# Altium schematic sheet StepGeneratorHammondMainRev1b.SchDoc(StepGeneratorHammondMainRev1b)
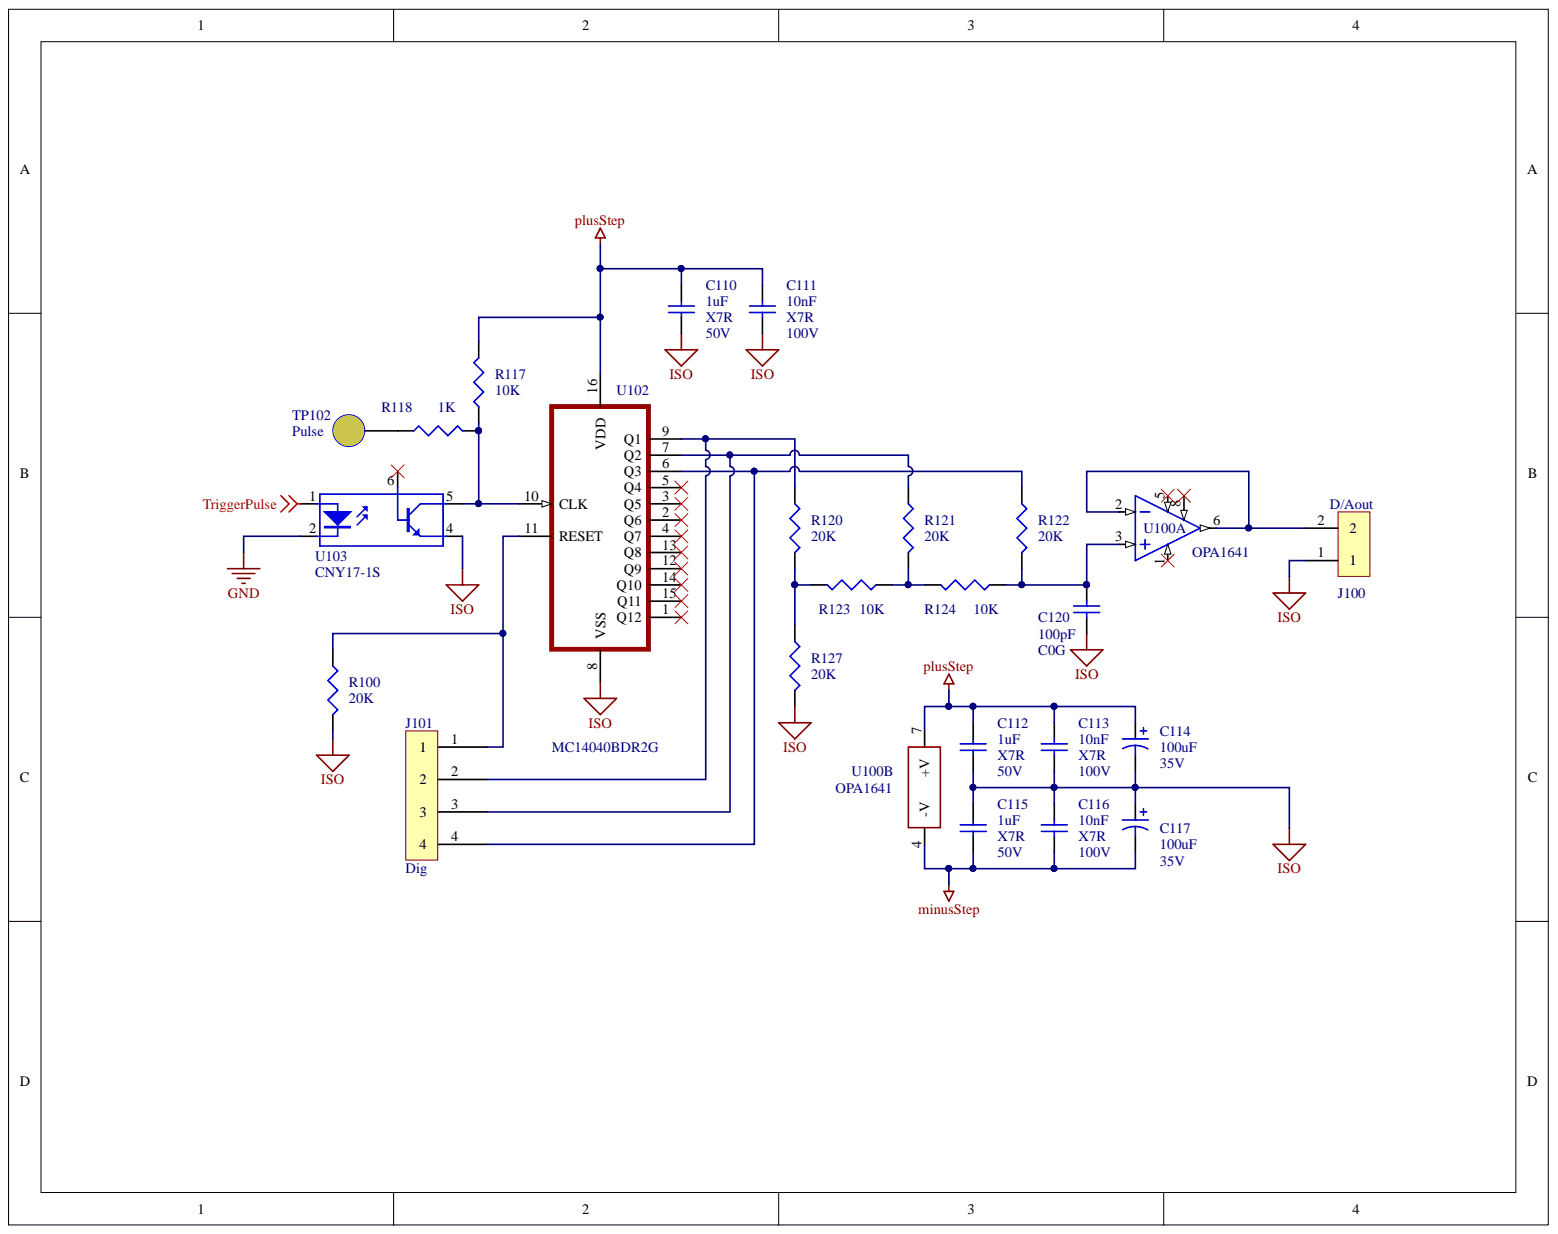
[diagram p0: StepGeneratorHammondMainRev1b.SchDoc]

## Parts
C110  1uF  Voltage Rating (DC): 50V  Dielectric: X7R  [CAPC3216M]
C111  10nF  Voltage Rating (DC): 100V  Dielectric: X7R  [CAPC3216M]
C112  1uF  Voltage Rating (DC): 50V  Dielectric: X7R  [CAPC3216M]
C113  10nF  Voltage Rating (DC): 100V  Dielectric: X7R  [CAPC3216M]
C114  100uF  Voltage Rating: 35V  [CAPPR2.5-6.3X10]
C115  1uF  Voltage Rating (DC): 50V  Dielectric: X7R  [CAPC3216M]
C116  10nF  Voltage Rating (DC): 100V  Dielectric: X7R  [CAPC3216M]
C117  100uF  Voltage Rating: 35V  [CAPPR2.5-6.3X10]
C120  value 100pF  Temperature Coefficient: C0G  [CAPC3216M]  (hidden comment: Cap)
J100  D/Aout  [DTA-100-2]
J101  Dig  [DTA-100-4]
R100  20K  [RESC3216M]
R117  10K  [RESC3216M]
R118  1K  [RESC3216M]
R120  20K  [RESC3216M]
R121  20K  [RESC3216M]
R122  20K  [RESC3216M]
R123  10K  [RESC3216M]
R124  10K  [RESC3216M]
R127  20K  [RESC3216M]
TP102  Pulse  [TP-.038]
U100  OPA1641  [SOIC127P600X168_HS-8N]  (parts A,B)
U102  MC14040BDR2G  [D16-M]
U103  CNY-17-1S  value CNY17-1S  [SOIC254P1000X6]

## Nets
GND: U103.2
ISO: C110.2 C111.1 C112.2 C113.1 C114.2 C115.1 C116.2 C117.1 C120.2 J100.1 R100.2 R127.2 U102.8(VSS) U103.4
minusStep: C115.2 C116.1 C117.2 U100.4(-V)
plusStep: C110.1 C111.2 C112.1 C113.2 C114.1 R117.1 U100.7(+V) U102.16(VDD)
TriggerPulse: U103.1
NetC120_1: C120.1 R122.2 R124.2 U100.3
NetJ100_2: J100.2 U100.2 U100.6
NetJ101_1: J101.1 R100.1 U102.11(RESET)
NetJ101_2: J101.2 R120.1 U102.9(Q1)
NetJ101_3: J101.3 R121.1 U102.7(Q2)
NetJ101_4: J101.4 R122.1 U102.6(Q3)
NetR117_2: R117.2 R118.2 U102.10(CLK) U103.5
NetR118_1: R118.1 TP102.1
NetR120_2: R120.2 R123.1 R127.1
NetR121_2: R121.2 R123.2 R124.1

Not connected: U100 1 5 8; U102 1(Q12) 2(Q6) 3(Q5) 4(Q7) 5(Q4) 12(Q9) 13(Q8) 14(Q10) 15(Q11); U103 6

## Library data of the parts
C110 C112 C115 = Cap: CAP CER 1UF 50V X7R 1206; Manufacturer Part Number: C1206C105K5RACTU; Manufacturer: KEMET; Tolerance: 10%; Dielectric Material: Ceramic; Width: 0.063inch; Voltage Rating: 50V; Voltage: 50V; Value: 1uF; Thickness: 0.043inch; Termination: SMD/SMT; Supplier Part Number: 399-8147-6-ND; Supplier: Digi-Key; Simulation: CAP; Signal Integrity: Cap; Series: C; REACH SVHC: No SVHC; Radiation Hardening: No; Packaging: Tape and Reel; Package Quantity: 1; Number of Pins: 2; Mount: Surface Mount; Min Operating Temperature: -55°C; Max Operating Temperature: 125°C; Library Name: Miscellaneous Devices.IntLib; Length: 3.2mm; Height: 1mm; Depth: 1.6mm; Construction: Flat; Component Kind: Standard; Case Code (Metric): 3216; Case Code (Imperial): 1206; Case/Package: 1206; Capacitance: 1uF
C111 C113 C116 = Cap: CAP CER 10NF 100V X7R 1206; Manufacturer Part Number: C1206C103K1RACTU; Manufacturer: KEMET; Tolerance: 10%; Dissipation Factor: 2.5%; Dielectric Material: Ceramic; Width: 0.063inch; Voltage Rating: 100V; Voltage: 100V; Value: 10nF; Thickness: 0.035inch; Termination: SMD/SMT; Supplier Part Number: 399-1236-6-ND; Supplier: Digi-Key; Simulation: CAP; Signal Integrity: Cap; Series: C; REACH SVHC: No SVHC; Radiation Hardening: No; Packaging: Tape and Reel; Package Quantity: 1; Number of Pins: 2; Mount: Surface Mount,PCB; Min Operating Temperature: -55°C; Max Operating Temperature: 125°C; Library Name: Miscellaneous Devices.IntLib; Length: 3.2mm; Height: 0.78mm; Depth: 1.6mm; Contact Plating: Tin,Matte; Construction: Flat; Component Kind: Standard; Case Code (Metric): 3216; Case Code (Imperial): 1206; Case/Package: 1206; Capacitance: 10nF
C114 C117 = Cap Pol1: CAP ALUM 100UF 20% 35V THRU HOLE; Manufacturer Part Number: 35YXJ100M6.3X11; Manufacturer: Rubycon; Ripple Current: 400mA; Polarity: Polar; Life (Hours): 7000Hour; Leakage Current: 3uA; Lead Diameter: 0.5mm; Impedance: 220mR; Voltage: 35V; Value: 100uF; Tolerance: 20%; Termination: Radial; Supplier Part Number: 1189-2260-ND; Supplier: Digi-Key; Simulation: CAP; Signal Integrity: Cap Pol; Radiation Hardening: No; Packaging: Bulk; Package Quantity: 3000; Mount: Through Hole; Min Operating Temperature: -40°C; Max Operating Temperature: 105°C; Library Name: Miscellaneous Devices.IntLib; Lead Pitch: 2.5mm; Height - Seated (Max): 0.492inch; Height: 11mm; Diameter: 6.3mm; Component Kind: Standard; Case/Package: Radial; Capacitance: 100uF
C120 = Cap: CAP CER 100PF 100V NP0 1206; Manufacturer Part Number: C1206C101J1GACTU; Manufacturer: Kemet; Tolerance: ±5%; Voltage - Rated: 100V; Thickness (Max): 0.035" (0.88mm); Supplier Part Number: 399-1206-1-ND; Supplier: Digi-Key; Size / Dimension: 0.126" L x 0.063" W (3.20mm x 1.60mm); Simulation: CAP; Signal Integrity: Cap; RoHS: RoHS Compliant; Packaging: Cut Tape (CT); Package / Case: 1206 (3216 Metric); Operating Temperature: -55°C ~ 125°C; Mounting Type: Surface Mount, MLCC; Library Name: Miscellaneous Devices.IntLib; Features: Low ESL; Component Kind: Standard; Category: Capacitors; Capacitance: 100pF; Applications: General Purpose
J100 = 2-Pin: CONN HEADER VERT 2POS 2.54MM; Manufacturer: TE Connectivity; Working Voltage: 250V; Value: 640456-2; Tail Length: 0.14inch; Strain Relief: No (Without); Plating Thickness: 3.81um; PCB Thickness: 0.063inch; PCB Mount Retention: Without; PCB Mounting Orientation: Vertical; Number of Positions: 2; Mating Connector Lock: Yes (With); Gender: Male; Current Rating: 5A; Contact Style: Straight; Contact Resistance: 6mR; Contact Post Length: 3.56mm; Connector Type: Connector,Pin,Plug,Header; Connector System: Wire-to-Board; Circuit Application: Signal; Approvals: CSA; Voltage Rating (AC): 250V; Voltage Rating: 250V; Termination: Solder; Supplier Part Number: A1921-ND; Supplier: Digi-Key; Shrouded: No (Without); Sealable: No (Without); REACH SVHC: No SVHC; Radiation Hardening: No; Pitch: 2.54mm; PCB Mount Alignment: No (Without); Panel Mount Retention: No (Without); Packaging: Bulk; Package Quantity: 5000; Orientation: Straight; Number of Rows: 1; Number of Poles: 2; Number of Contacts: 2; Mounting Ears: No (Without); Mount: Through Hole; Min Operating Temperature: -67°C; Max Voltage Rating (AC): 250V; Max Operating Temperature: 221°C; Mating Retention: No (Without); Mating Alignment: No (Without); Manufacturer Part Number: 640456-2; Library Name: MY_CONNECTORS.SchLib; Length: 7.62mm; Lead Pitch: 2.54mm; Lead Free: Lead Free; Insulation Material: Thermoplastic; Insulation Color: Natural; Housing Material: Polyester; Housing Color: Natural; Height: 7.87mm; Flammability Rating: UL94 V-0; Fastening Type: Friction; ELV: Compliant; Depth: 5.72mm; Contact Shape: Square; Contact Retention: No (Without); Contact Plating: Tin; Contact Mating Length: 7.49mm; Contact Material: Copper Alloy; Contact Gender: Male; Contact Current Rating: 5A; Component Kind: Standard; Color: Natural,White,Beige; Backwall/Post Interruptions: No (Without)
J101 = 4-Pin: CONN HEADER VERT 4POS 2.54MM; Manufacturer: TE Connectivity; Working Voltage: 250V; Value: 640456-4; Tail Length: 0.14inch; Strain Relief: No (Without); Plating Thickness: 3.81um; PCB Thickness: 0.063inch; PCB Mount Retention: Without; PCB Mounting Orientation: Vertical; Number of Positions: 4; Mating Connector Lock: Yes (With); Current Rating: 5A; Contact Style: Straight; Contact Resistance: 6mR; Contact Post Length: 3.56mm; Connector Type: Connector,Pin,Plug,Header; Connector System: Wire-to-Board; Circuit Application: Signal; Approvals: CSA; Voltage Rating (AC): 250V; Voltage Rating: 250V; Termination: Solder; Supplier Part Number: A1922-ND; Supplier: Digi-Key; Shrouded: No (Without); Sealable: No (Without); REACH SVHC: No SVHC; Radiation Hardening: No; Pitch: 2.54mm; PCB Mount Alignment: No (Without); Panel Mount Retention: No (Without); Packaging: Bulk; Package Quantity: 5000; Orientation: Straight; Number of Rows: 1; Number of Poles: 4; Number of Contacts: 4; Mounting Ears: No (Without); Mount: Through Hole; Min Operating Temperature: -67°C; Max Voltage Rating (AC): 250V; Max Operating Temperature: 221°C; Mating Retention: No (Without); Mating Alignment: No (Without); Manufacturer Part Number: 640456-4; Library Name: MY_CONNECTORS.SchLib; Length: 12.7mm; Lead Pitch: 2.54mm; Housing Material: Polyester; Housing Color: Natural; Height: 7.87mm; Flammability Rating: UL94 V-0; Fastening Type: Friction; Depth: 5.72mm; Contact Shape: Square; Contact Retention: No (Without); Contact Plating: Tin; Contact Mating Length: 7.49mm; Contact Material: Copper Alloy; Contact Gender: Male; Contact Current Rating: 5A; Component Kind: Standard; Color: Natural,Beige,White; Backwall/Post Interruptions: No (Without)
R100 R120-R122 R127 = Res3: RES 20K OHM 1% 1/4W 1206; Manufacturer Part Number: RMCF1206FT20K0; Manufacturer: Stackpole Electronics; Temperature Coefficient: 100ppm/°C; Resistance: 20kR; Max Power Dissipation: 250mW; Width: 0.063inch; Value: 20K; Tolerance: 1%; Supplier Part Number: RMCF1206FT20K0CT-ND; Supplier: Digi-Key; Simulation: RESISTOR; Signal Integrity: Res; Radiation Hardening: No; Packaging: Tape and Reel; Number of Terminations: 2; Mount: Surface Mount; Min Operating Temperature: -55°C; Military Standard: Not; Max Operating Temperature: 155°C; Library Name: Miscellaneous Devices.IntLib; Length: 3.2mm; Lead Free: Lead Free; Height: 0.028inch; Features: Automotive AEC-Q200; Depth: 1.6mm; Contact Plating: Matte,Tin; Composition: Thick Film; Component Kind: Standard; Case Code (Metric): 3216; Case Code (Imperial): 1206; Case/Package: 1206
R117 R123 R124 = Res3: RES 10K OHM 1% 1/4W 1206; Manufacturer Part Number: RMCF1206FT10K0; Manufacturer: Stackpole Electronics; Voltage Rating (DC): 200V; Temperature Coefficient: 100ppm/°C; Resistance: 10kR; Power Rating: 250mW; Width: 0.063inch; Voltage Rating: 200V; Value: 10K; Tolerance: 1%; Termination: SMD/SMT; Supplier Part Number: RMCF1206FT10K0CT-ND; Supplier: Digi-Key; Simulation: RESISTOR; Signal Integrity: Res; REACH SVHC: No SVHC; Radiation Hardening: No; Packaging: Tape and Reel; Package Quantity: 1; Number of Terminations: 2; Number of Pins: 2; Mount: Surface Mount; Min Operating Temperature: -55°C; Military Standard: Not; Max Power Dissipation: 250mW; Max Operating Temperature: 155°C; Library Name: Miscellaneous Devices.IntLib; Length: 3.2mm; Lead Free: Lead Free; Height: 0.028inch; Features: Automotive AEC-Q200; Depth: 1.6mm; Contact Plating: Matte,Tin; Composition: Thick Film; Component Kind: Standard; Case Code (Metric): 3216; Case Code (Imperial): 1206; Case/Package: 1206
R118 = Res3: RES 1K OHM 1% 1/4W 1206; Manufacturer Part Number: RMCF1206FT1K00; Manufacturer: Stackpole Electronics; Temperature Coefficient: 100ppm/°C; Resistance: 1kR; Power Rating: 250mW; Width: 0.063inch; Value: 1K; Tolerance: 1%; Supplier Part Number: RMCF1206FT1K00CT-ND; Supplier: Digi-Key; Simulation: RESISTOR; Signal Integrity: Res; Radiation Hardening: No; Packaging: Tape and Reel; Number of Terminations: 2; Mount: Surface Mount; Min Operating Temperature: -55°C; Military Standard: Not; Max Power Dissipation: 250mW; Max Operating Temperature: 155°C; Library Name: Miscellaneous Devices.IntLib; Length: 3.2mm; Lead Free: Lead Free; Height: 0.028inch; Features: Automotive AEC-Q200; Depth: 1.6mm; Contact Plating: Matte,Tin; Composition: Thick Film; Component Kind: Standard; Case Code (Metric): 3216; Case Code (Imperial): 1206; Case/Package: 1206
TP102 = TP-Round-Yellow: TP Thru-Hole; Manufacturer Part Number: Gold; Manufacturer: UW; Value: TP Thru-Hole; Supplier Part Num,ber: Gold; Supplier: UW; Library Name: MY_TP.SchLib; Component Kind: Standard
U100 = Single OP Amp separate supply.: IC OPAMP AUDIO 11MHZ RRO 8SOIC; Manufacturer Part Number: OPA1641AIDR; Manufacturer: Texas Instruments; Voltage Gain: 134dB; Value: OPA1641AIIDR; Slew Rate: 20V/µs; Power Supply Rejection Ratio (PSRR): 137.08dB; Output Current per Channel: 36mA; Operating Supply Current: 1.8mA; Number of Elements: 1; Min Supply Voltage: 4.5V; Min Dual Supply Voltage: 2.25V; Max Supply Voltage: 36V; Max Dual Supply Voltage: 18V; Input Offset Voltage (Vos): 3.5mV; Input Bias Current: 2pA; Common Mode Rejection Ratio: 120dB; Unity Gain Bandwidth Product: 11MHz; Supplier Part Number: 296-48624-1-ND; Supplier: Digi-Key; Radiation Hardening: No; Packaging: Tape and Reel; Package Quantity: 2500; Number of Pins: 8; Number of Circuits: 1; Number of Channels: 1; Nominal Supply Current: 1.8mA; Nominal Gain Bandwidth Product: 11MHz; Min Operating Temperature: -40°C; Max Operating Temperature: 85°C; Library Name: My_OpAmps.SchLib; Lead Free: Lead Free; Gain Bandwidth Product: 11MHz; Component Kind: Standard; Case/Package: D
U102 = CD4040BM96: IC COUNTER 12BIT CMOS 16SOIC; Manufacturer: ON Semiconductor; Vendor: Texas Instruments; Value: 4040; Turn-On Delay Time: 3250ns; Quiescent Current: 20uA; Power Dissipation: 500mW; Number of Output Lines: 12; Number of Inputs: 1; Min Supply Voltage: 3V; Max Supply Voltage: 18V; Low Level Output Current: 8.8mA; High Level Output Current: -8.8mA; Frequency: 10MHz; Direction: Up,Unidirectional; Clock Edge Trigger Type: Negative Edge; Capacitance: 7.5pF; Built_By: EMA_UL_Team; Width: 4mm; Type: DEV; Supplier Part Number: MC14040BDR2GOSCT-ND; Supplier: Digi-Key; RefDes: RefDes; REACH SVHC: No SVHC; Radiation Hardening: No; Propagation Delay: 3250ns; Packaging: Tape and Reel; Package Quantity: 2500; Number of Pins: 16; Number of Input Lines: 1; Number of Elements: 1; Number of Circuits: 1; Number of Bits per Element: 12; Number of Bits: 12; Mount: Surface Mount; Min Operating Temperature: -55°C; Max Operating Temperature: 125°C; Manufacturer Part Number: MC14040BDR2G; Logic Function: Counter; Library Name: 4040.SchLib; Length: 10mm; Lead Free: Lead Free; Height: 1.5mm; Halogen Free: Halogen Free; Contact Plating: Tin,Matte; Component Kind: Standard; Case/Package: SOIC; Copyright: Copyright (C) 2018 Accelerated Designs. All rights reserved
U103 = CYN17: OPTOISO 5KV TRANS W/BASE 6SMD; Manufacturer: Vishay Lite-On; Rise Time: 10000ns; Reverse Breakdown Voltage: 6V; Power Dissipation: 250mW; Output Voltage: 70V; Output Current per Channel: 150mA; Number of Elements: 1; Max Input Current: 60mA; Forward Voltage: 1.45V; Current Transfer Ratio: 80%; Collector Emitter Saturation Voltage: 300mV; Supplier Part Number: 160-1309-5-ND; Supplier: Digi-Key; Packaging: Rail/Tube; Package Quantity: 65; Number of Pins: 6; Number of Circuits: 1; Number of Channels: 1; Mount: Surface Mount; Min Operating Temperature: -55°C; Max Power Dissipation: 250mW; Max Output Voltage: 70V; Max Operating Temperature: 100°C; Max Collector Current: 150mA; Manufacturer Part Number: CNY17-1S; Library Name: MY_Opto.SchLib; Lead Free: Lead Free; Forward Current: 60mA; Fall Time: 10000ns; Component Kind: Standard; Collector Emitter Voltage (VCEO): 70V; Case/Package: SMD/SMT
